# Altium schematic sheet MPU6050.SchDoc(MPU6050)
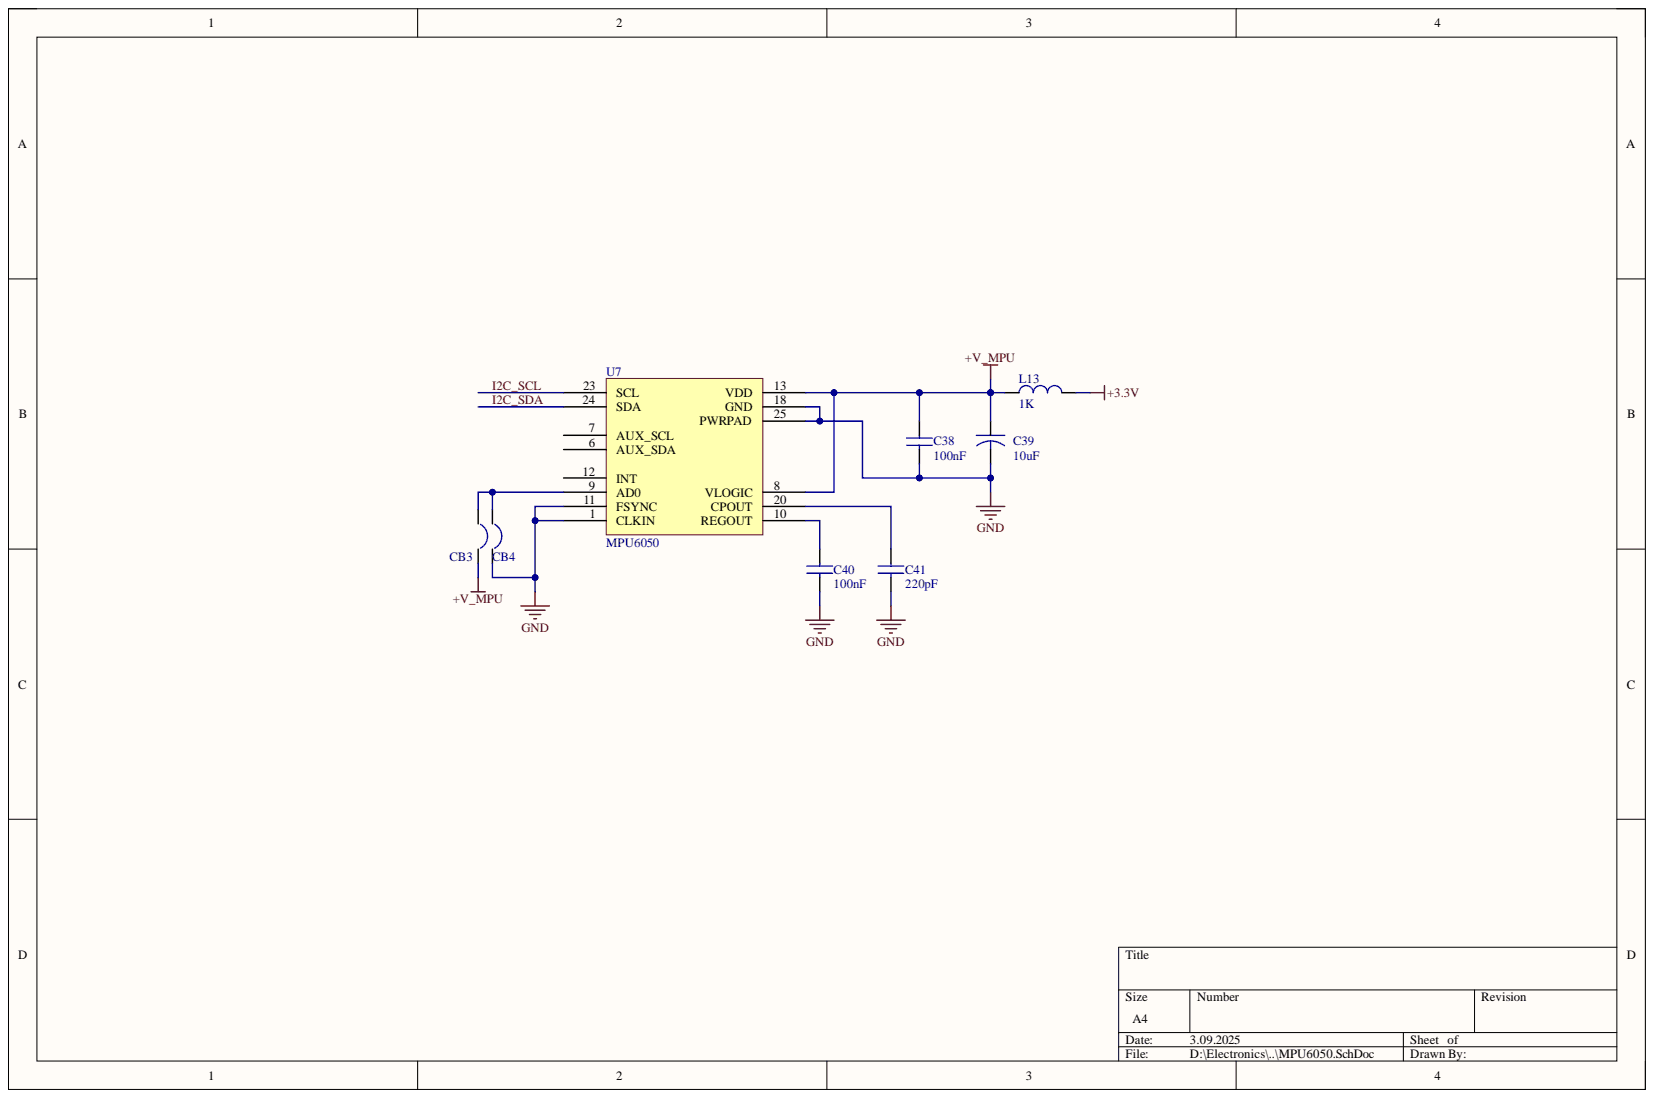
[diagram p0: MPU6050.SchDoc]

## Parts
C38  value 100nF  [0805 SMD Ceramic Capacitor]  (hidden comment: C0805C104)
C39  value 10uF  [A Case]  (hidden comment: T491A106)
C40  value 100nF  [0805 SMD Ceramic Capacitor]  (hidden comment: C0805C104)
C41  value 220pF  [0805 SMD Ceramic Capacitor]  (hidden comment: C0805C221)
CB3  CircuitBraker  [CircuitBraker]  (value not drawn)
CB4  CircuitBraker  [CircuitBraker]  (value not drawn)
L13  value 1K  [0805 Inductor]  (hidden comment: 0805Ferrite)
U7  MPU-6050  value MPU6050  [QFN-24]

## Nets
+3.3V: L13.1
+V_MPU: C38.1 C39.1 CB3.1 L13.2 U7.8(VLOGIC) U7.13(VDD)
GND: C38.2 C39.2 C40.2 C41.2 CB4.1 U7.1(CLKIN) U7.11(FSYNC) U7.18(GND) U7.25(PWRPAD)
I2C_SCL: U7.23(SCL)
I2C_SDA: U7.24(SDA)
NetC40_1: C40.1 U7.10(REGOUT)
NetC41_1: C41.1 U7.20(CPOUT)
NetCB3_2: CB3.2 CB4.2 U7.9(AD0)

Not connected: U7 6(AUX_SDA) 7(AUX_SCL) 12(INT)

## Library data of the parts
C38 C40 = C0805C104: Manufacturer: KEMET; Manufacturer Code: C0805C104J5RACTU; Voltage: 50V; Component Kind: Standard; Farnell Code: 1414663; Library Name: Capacitors.SchLib; Package: 0805; Unit Price: 0,06 €
C39 = T491A106: Manufacturer: KEMET; Manufacturer Code: T491A106K006AT; Voltage: 6V3; Component Kind: Standard; Farnell Code: 1457412; Library Name: Capacitors.SchLib; Unit Price: 0,143 €
C41 = C0805C221: Manufacturer: YAGEO; Manufacturer Code: CC0805JRNPO0BN221; Tolerace: ± 5%; Voltage: 100V; Component Kind: Standard; Library Name: Capacitors.SchLib; Package: 0805; Price Unit: $; Unit Price: 0,00832
L13 = 0805Ferrite: Manufacturer: TDK; Manufacturer Code: MMZ2012R102A; Current: 500mA; Component Kind: Standard; Farnell Code: 1669719; Library Name: FerriteBead.SchLib; Unit Price: 0,059 €
U7 = MPU-6050: Manufacturer: Invensense; Spec: Tri-Axis Gyroscope, Tri-Axis Accelerometer; Component Kind: Standard; Farnell Code: 1864742; Library Name: MPU-6050.SchLib; Manufacturer Code: MPU-6050; Unit Price: 27,79 €
CB3 CB4 = CircuitBraker: Component Kind: Standard; Description: CircuitBraker; Library Name: CircuitBraker.IntLib
